# Altium schematic sheet Measuring_Voltage.SchDoc
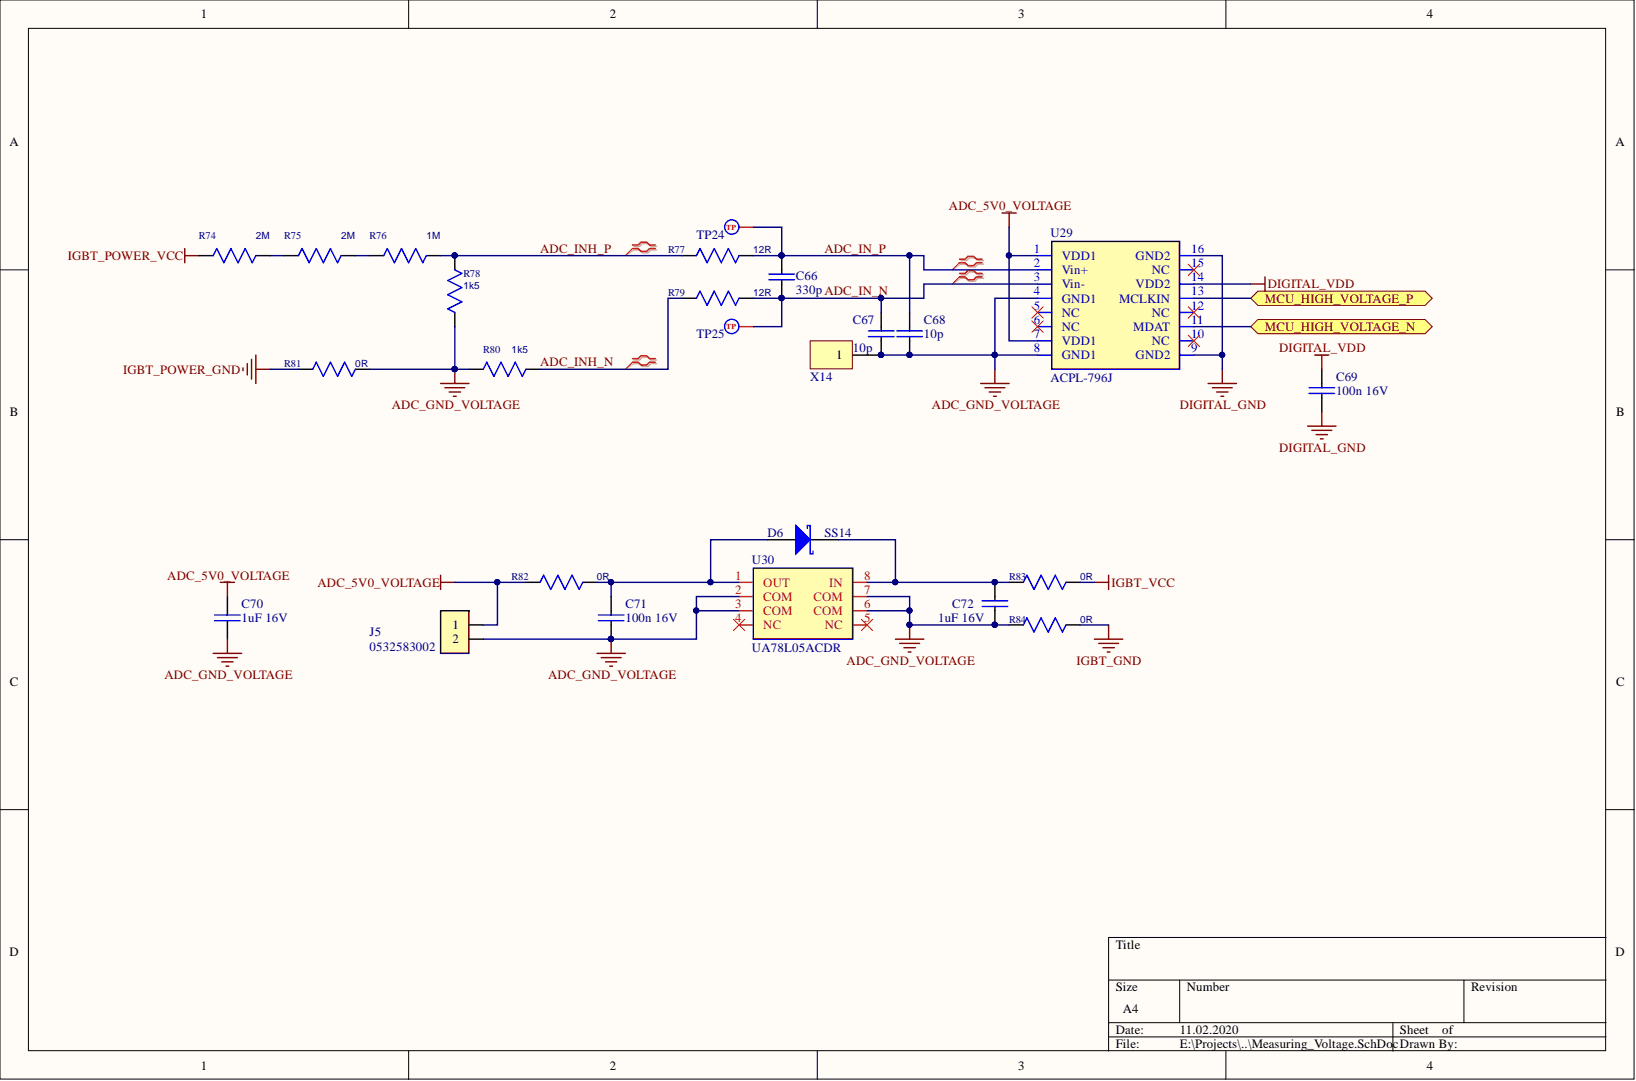
[diagram p0: Measuring_Voltage.SchDoc]

## Parts
C66  330p  [C_0805]
C67  10p  [C_0805]
C68  10p  [C_0805]
C69  100n 16V  [C_0805]
C70  1uF 16V  [C_0805]
C71  100n 16V  [C_0805]
C72  1uF 16V  [C_0805]
D6  SS14  [SMA]
J5  0532583002  [Molex 0532583002]
R74  2M  [R_1206]
R75  2M  [R_1206]
R76  1M  [R_1206]
R77  12R  [R_0805]
R78  1k5  [R_0805]
R79  12R  [R_0805]
R80  1k5  [R_0805]
R81  0R  [R_0805]
R82  0R  [R_0805]
R83  0R  [R_0805]
R84  0R  [R_0805]
TP24  TEST_POINT  [TEST POINT D1.5]  (value not drawn)
TP25  TEST_POINT  [TEST POINT D1.5]  (value not drawn)
U29  ACPL-796J  [SOIC-16-300]
U30  UA78L05ACDR  [SOIC-8_N]
X14  PLS-1  [PLS-1-3D]  (value not drawn)

## Ports
MCU_HIGH_VOLTAGE_N, MCU_HIGH_VOLTAGE_P

## Nets
ADC_5V0_VOLTAGE: C70.2 J5.1 R82.2 U29.1(VDD1) U29.7(VDD1)
ADC_GND_VOLTAGE: C67.2 C68.1 C70.1 C71.1 C72.1 J5.2 R78.2 R80.1 R81.1 R84.1 U29.4(GND1) U29.8(GND1) U30.2(COM) U30.3(COM) U30.6(COM) U30.7(COM) X14.1
DIGITAL_GND: C69.1 U29.9(GND2) U29.16(GND2)
DIGITAL_VDD: C69.2 U29.14(VDD2)
IGBT_GND: R84.2
IGBT_POWER_GND: R81.2
IGBT_POWER_VCC: R74.2
IGBT_VCC: R83.2
ADC_IN_N: C66.1 C67.1 R79.2 TP25.1 U29.3(Vin-)
ADC_IN_P: C66.2 C68.2 R77.2 TP24.1 U29.2(Vin+)
ADC_INH_N: R79.1 R80.2
ADC_INH_P: R76.1 R77.1 R78.1
MCU_HIGH_VOLTAGE_N: U29.11(MDAT) ; port MCU_HIGH_VOLTAGE_N
MCU_HIGH_VOLTAGE_P: U29.13(MCLKIN) ; port MCU_HIGH_VOLTAGE_P
NetC71_2: C71.2 D6.1 R82.1 U30.1(OUT)
NetC72_2: C72.2 D6.2 R83.1 U30.8(IN)
NetR74_1: R74.1 R75.2
NetR75_1: R75.1 R76.2

Not connected: U29 5(NC) 6(NC) 10(NC) 12(NC) 15(NC); U30 4(NC) 5(NC)

## Library data of the parts
C66-C72 = Cap NP: CERAMIC CAPACITOR; Component Kind: Standard; Library Name: Capacitors.SchLib
  C66: Value: 330p
  C67 C68: Value: 10p
  C69 C71: Value: 100n 16V
  C70 C72: Value: 1uF 16V
D6 = D Schottky: Schottky Diode; Code_JEDEC: DO-214-AA; Component Kind: Standard; LatestRevisionDate: 17-Jul-2002; LatestRevisionNote: Re-released for DXP Platform.; Library Name: Diode.SchLib; PackageDescription: DO-214-AA/SMB; 2 C-Bend Leads; Body 5.3 x 3.6 mm, inc. leads (LxW); PackageReference: SMB; Published: 8-Jun-2000; Signal Integrity: D Schottky; Simulation: SKYDIODE; Publisher: Altium Limited
J5 = Molex 0532583002: CONN HEADER VERT 2POS 3.5MM; Component Kind: Standard; Library Name: Connectors.SchLib; Value: 0532583002
R74-R76 = R1206: Resistor; Component Kind: Standard; Library Name: Resistors.SchLib
  R74 R75: Value: 2M
  R76: Value: 1M
R77-R84 = R0805: Resistor; Component Kind: Standard; Library Name: Resistors.SchLib
  R77 R79: Value: 12R
  R78 R80: Value: 1k5
  R81-R84: Value: 0R
TP24 TP25 = TEST_POINT: Signal Test Point; Component Kind: Standard; Library Name: Discrete.SchLib
U29 = ACPL-796J: Optically Isolated Sigma-Delta Modulator; Component Kind: Standard; Library Name: AnalogToDigital.SchLib; Value: ACPL-796J
U30 = UA78L05ACDR: s Positive-Voltage Linear Regulators; Component Kind: Standard; Library Name: Power.SchLib; Value: UA78L05ACDR
X14 = PLS-1: Header, 1-Pin; Component Kind: Standard; LatestRevisionDate: 17-Jul-2002; LatestRevisionNote: Re-released for DXP Platform.; Library Name: Connectors.SchLib; Publisher: Altium Limited
